# Altium schematic sheet 1_MainController_rB0.SchDoc
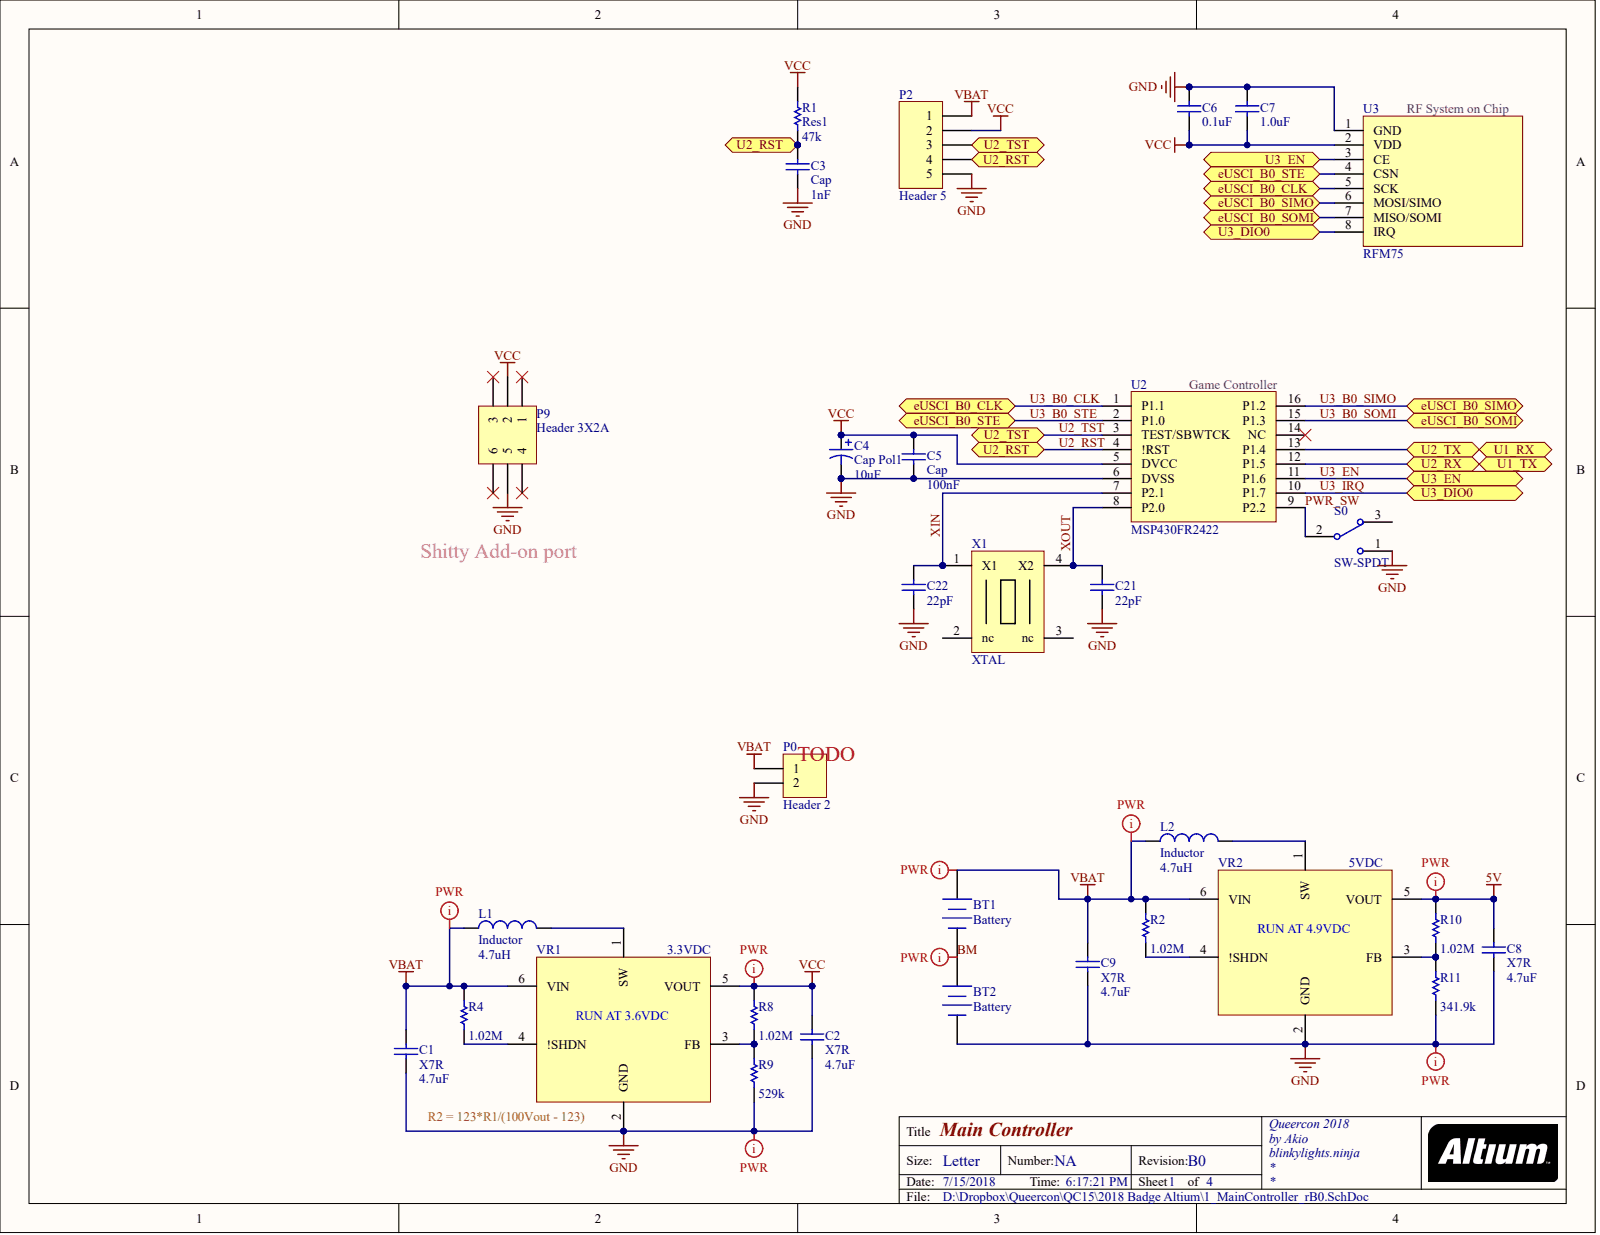
[diagram p0: 1_MainController_rB0.SchDoc]

## Parts
BT1  Battery
BT2  Battery
C1  X7R  value 4.7uF
C2  X7R  value 4.7uF
C3  Cap  value 1nF
C4  Cap Pol1  value 10uF
C5  Cap  value 100nF
C6  value 0.1uF  (hidden comment: Cap)
C7  value 1.0uF  (hidden comment: Cap)
C8  X7R  value 4.7uF
C9  X7R  value 4.7uF
C21  value 22pF  (hidden comment: Cap)
C22  value 22pF  (hidden comment: Cap)
L1  Inductor  value 4.7uH
L2  Inductor  value 4.7uH
P0  Header 2
P2  Header 5
P9  Header 3X2A
R1  Res1  value 47k
R2  value 1.02M  (hidden comment: Res1)
R4  value 1.02M  (hidden comment: Res1)
R8  value 1.02M  (hidden comment: Res1)
R9  value 529k  (hidden comment: Res1)
R10  value 1.02M  (hidden comment: Res1)
R11  value 341.9k  (hidden comment: Res1)
S0  SW-SPDT
U2  MSP430FR2422
U3  RFM75
VR1  3.3VDC
VR2  5VDC
X1  XTAL

## Ports
EUSCI_B0_CLK, EUSCI_B0_SIMO, EUSCI_B0_SOMI, EUSCI_B0_STE, U1_RX, U1_TX, U2_RST, U2_RX, U2_TST, U2_TX, U3_DIO0, U3_EN

## Nets
5V: C8.2 R10.2 VR2.5(VOUT)
GND: BT2.2 C1.1 C2.1 C3.1 C4.2 C5.1 C6.2 C7.2 C8.1 C9.1 C21.2 C22.1 P0.2 P2.5 P9.5 R9.1 R11.1 S0.1 U2.6(DVSS) U3.1(GND) VR1.2(GND) VR2.2(GND)
VBAT: BT1.1 C1.2 C9.2 L1.1 L2.1 P0.1 P2.1 R2.2 R4.2 VR1.6(VIN) VR2.6(VIN)
VCC: C2.2 C4.1 C5.2 C6.1 C7.1 P2.2 P9.2 R1.2 R8.2 U2.5(DVCC) U3.2(VDD) VR1.5(VOUT)
BM: BT1.2 BT2.1
PWR_SW: S0.2 U2.9(P2.2)
U1_RX: U2.13(P1.4) ; port U1_RX, port U2_TX
U1_TX: U2.12(P1.5) ; port U1_TX, port U2_RX
U2_RST: C3.2 P2.4 R1.1 U2.4(!RST) ; port U2_RST
U2_TST: P2.3 U2.3(TEST/SBWTCK) ; port U2_TST
U3_B0_CLK: U2.1(P1.1) U3.5(SCK) ; port EUSCI_B0_CLK
U3_B0_SIMO: U2.16(P1.2) U3.6(MOSI/SIMO) ; port EUSCI_B0_SIMO
U3_B0_SOMI: U2.15(P1.3) U3.7(MISO/SOMI) ; port EUSCI_B0_SOMI
U3_B0_STE: U2.2(P1.0) U3.4(CSN) ; port EUSCI_B0_STE
U3_EN: U2.11(P1.6) U3.3(CE) ; port U3_EN
U3_IRQ: U2.10(P1.7) U3.8(IRQ) ; port U3_DIO0
XIN: C22.2 U2.7(P2.1) X1.1
XOUT: C21.1 U2.8(P2.0) X1.4(X2)
NetL1_2: L1.2 VR1.1(SW)
NetL2_2: L2.2 VR2.1(SW)
NetR2_1: R2.1 VR2.4(!SHDN)
NetR4_1: R4.1 VR1.4(!SHDN)
NetR8_1: R8.1 R9.2 VR1.3(FB)
NetR10_1: R10.1 R11.2 VR2.3(FB)

Not connected: P9 1 3 4 6; S0 3; U2 14(NC); X1 2(nc) 3(nc)

## Library data of the parts
L1 L2 = (no symbol name): Code_IEC: 1005; Value: 4.7uH; Published: 8-Jun-2000; LatestRevisionDate: 29-May-2009; LatestRevisionNote: IPC-7351 Footprint Added.; PackageReference: 0402-A; PackageDescription: Chip Inductor; Code_JEITA: 0402; Publisher: Altium Limited
BT1 BT2 C1-C9 C21 C22 P0 P2 P9 R1 R2 R4 R8-R11 S0 U2 U3 VR1 VR2 X1 = (no symbol name)
  BT1 BT2: Published: 24-Mar-1999; LatestRevisionDate: 17-Jul-2002; LatestRevisionNote: Re-released for DXP Platform.; PackageReference: BAT-2; PackageDescription: Battery; 2 Leads
  C1-C3 C5-C9 C21 C22: Published: 8-Jun-2000; LatestRevisionDate: 17-Jul-2002; LatestRevisionNote: Re-released for DXP Platform.; PackageReference: RAD-0.3; PackageDescription: Radial Cap, Thru-Hole; 2 Leads; 0.3 in Pin Spacing
  C4: Published: 8-Jun-2000; LatestRevisionDate: 17-Jul-2002; LatestRevisionNote: Re-released for DXP Platform.; PackageReference: RB7.6-15; PackageDescription: Polarized Capacitor (Radial); 2 Leads
  P0 P2 P9: LatestRevisionDate: 17-Jul-2002; LatestRevisionNote: Re-released for DXP Platform.
  R1 R2 R4 R8-R11: Published: 8-Jun-2000; LatestRevisionDate: 17-Jul-2002; LatestRevisionNote: Re-released for DXP Platform.; PackageReference: AXIAL-0.3; PackageDescription: Axial Device, Thru-Hole; 2 Leads; 0.3 in Pin Spacing
  S0: Published: 24-Mar-1999; LatestRevisionDate: 17-Dec-2003; LatestRevisionNote: Schematic revised, PCB Footprint replaced.; DatasheetVersion: 05-Dec-2000; PackageReference: TL36WW15050; PackageDescription: Switch; 4 Leads; ComponentLink1Description: Manufacturer Link; ComponentLink1URL: http://www.apem.com
  BT1 BT2 C1-C9 C21 C22 P0 P2 P9 R1 R2 R4 R8-R11 S0: Publisher: Altium Limited
